# Altium schematic sheet auxiliary.SchDoc(auxiliary)
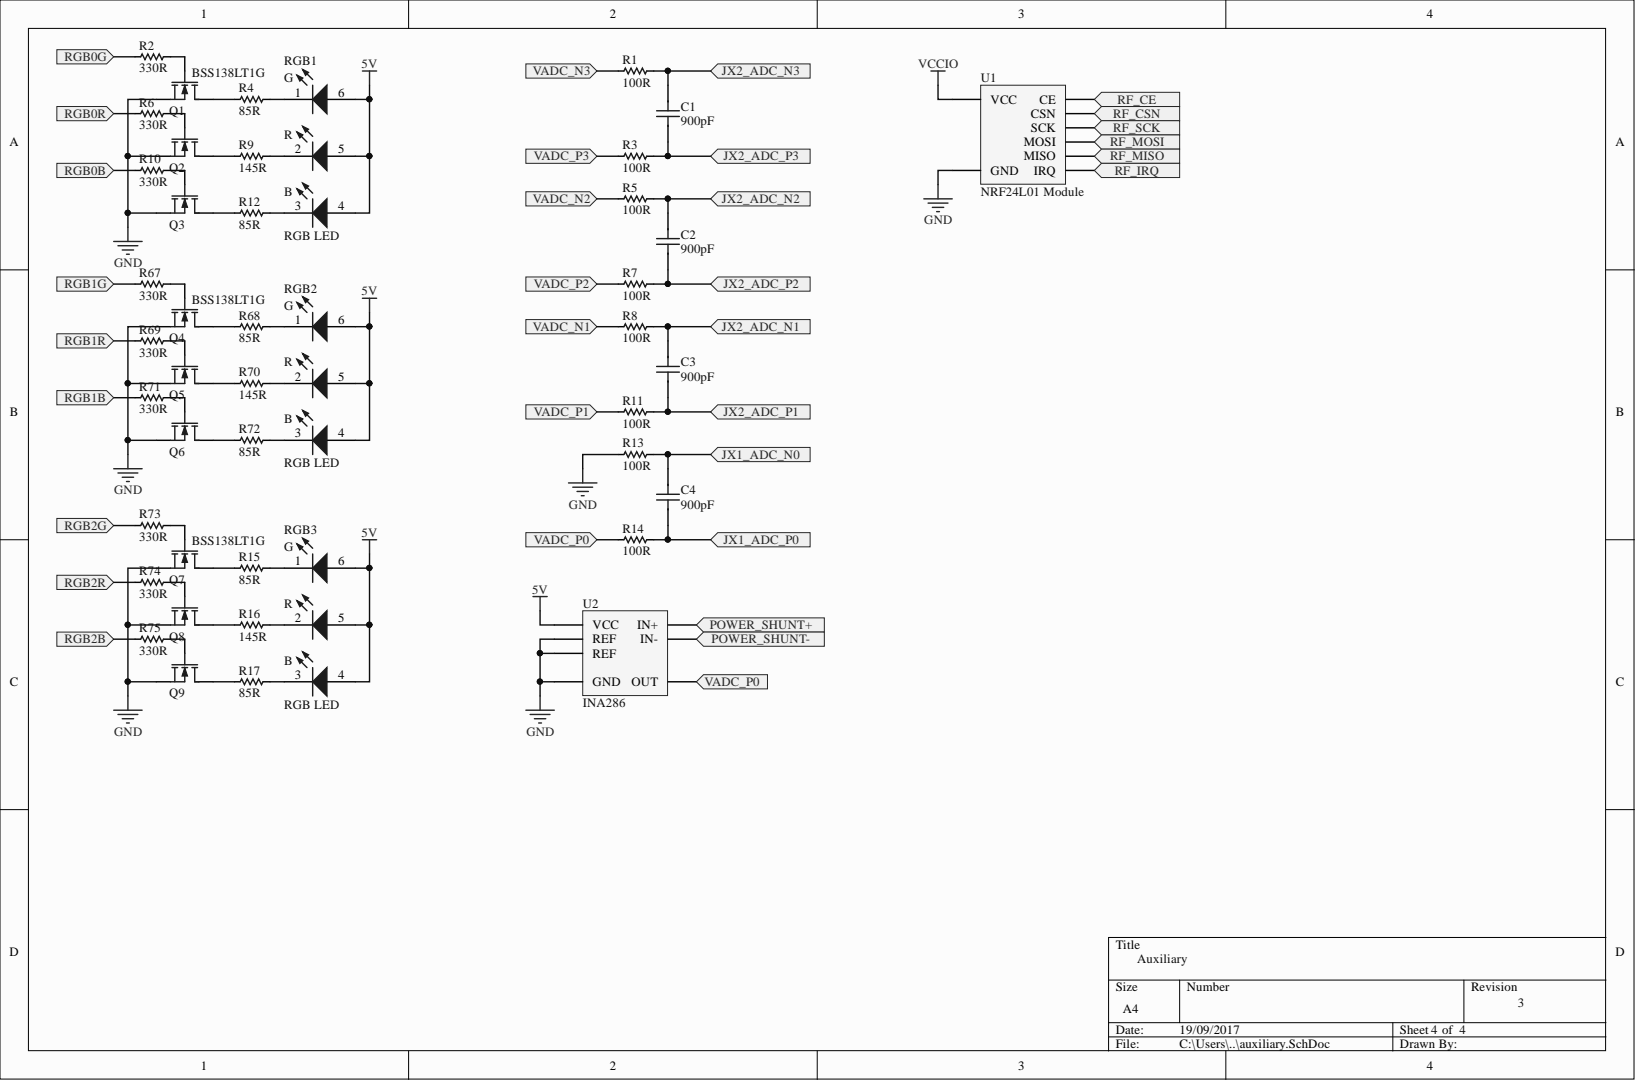
[diagram p0: auxiliary.SchDoc]

## Parts
C1  value 900pF  [CAP-0402]  (hidden comment: Capacitor)
C2  value 900pF  [CAP-0402]  (hidden comment: Capacitor)
C3  value 900pF  [CAP-0402]  (hidden comment: Capacitor)
C4  value 900pF  [CAP-0402]  (hidden comment: Capacitor)
Q1  BSS138LT1G  [SOT-23B]
Q2  BSS138LT1G  [SOT-23B]
Q3  BSS138LT1G  [SOT-23B]
Q4  BSS138LT1G  [SOT-23B]
Q5  BSS138LT1G  [SOT-23B]
Q6  BSS138LT1G  [SOT-23B]
Q7  BSS138LT1G  [SOT-23B]
Q8  BSS138LT1G  [SOT-23B]
Q9  BSS138LT1G  [SOT-23B]
R1  value 100R  [RES-0402]  (hidden comment: Resistor)
R2  value 330R  [RES-0805]  (hidden comment: Resistor)
R3  value 100R  [RES-0402]  (hidden comment: Resistor)
R4  value 85R  [RES-0805]  (hidden comment: Resistor)
R5  value 100R  [RES-0402]  (hidden comment: Resistor)
R6  value 330R  [RES-0805]  (hidden comment: Resistor)
R7  value 100R  [RES-0402]  (hidden comment: Resistor)
R8  value 100R  [RES-0402]  (hidden comment: Resistor)
R9  value 145R  [RES-0805]  (hidden comment: Resistor)
R10  value 330R  [RES-0805]  (hidden comment: Resistor)
R11  value 100R  [RES-0402]  (hidden comment: Resistor)
R12  value 85R  [RES-0805]  (hidden comment: Resistor)
R13  value 100R  [RES-0402]  (hidden comment: Resistor)
R14  value 100R  [RES-0402]  (hidden comment: Resistor)
R15  value 85R  [RES-0805]  (hidden comment: Resistor)
R16  value 145R  [RES-0805]  (hidden comment: Resistor)
R17  value 85R  [RES-0805]  (hidden comment: Resistor)
R67  value 330R  [RES-0805]  (hidden comment: Resistor)
R68  value 85R  [RES-0805]  (hidden comment: Resistor)
R69  value 330R  [RES-0805]  (hidden comment: Resistor)
R70  value 145R  [RES-0805]  (hidden comment: Resistor)
R71  value 330R  [RES-0805]  (hidden comment: Resistor)
R72  value 85R  [RES-0805]  (hidden comment: Resistor)
R73  value 330R  [RES-0805]  (hidden comment: Resistor)
R74  value 330R  [RES-0805]  (hidden comment: Resistor)
R75  value 330R  [RES-0805]  (hidden comment: Resistor)
RGB1  RGB LED  [RGB_LED]
RGB2  RGB LED  [RGB_LED]
RGB3  RGB LED  [RGB_LED]
U1  NRF24L01 Module  [NRF24L01 Module]
U2  INA286  [SOIC-8]

## Ports
JX1_ADC_N0, JX1_ADC_P0, JX2_ADC_N1, JX2_ADC_N2, JX2_ADC_N3, JX2_ADC_P1, JX2_ADC_P2, JX2_ADC_P3, POWER_SHUNT+, POWER_SHUNT-, RF_CE, RF_CSN, RF_IRQ, RF_MISO, RF_MOSI, RF_SCK, RGB0B, RGB0G, RGB0R, RGB1B, RGB1G, RGB1R, RGB2B, RGB2G, RGB2R, VADC_N1, VADC_N2, VADC_N3, VADC_P0, VADC_P1, VADC_P2, VADC_P3

## Nets
5V: RGB1.4 RGB1.5 RGB1.6 RGB2.4 RGB2.5 RGB2.6 RGB3.4 RGB3.5 RGB3.6 U2.6(VCC)
GND: Q1.2 Q2.2 Q3.2 Q4.2 Q5.2 Q6.2 Q7.2 Q8.2 Q9.2 R13.1 U1.2(GND) U2.2(GND) U2.3(REF) U2.7(REF)
VCCIO: U1.1(VCC)
JX1_ADC_N0: C4.2 R13.0 ; port JX1_ADC_N0
JX1_ADC_P0: C4.1 R14.0 ; port JX1_ADC_P0
JX2_ADC_N1: C3.2 R8.0 ; port JX2_ADC_N1
JX2_ADC_N2: C2.2 R5.0 ; port JX2_ADC_N2
JX2_ADC_N3: C1.2 R1.0 ; port JX2_ADC_N3
JX2_ADC_P1: C3.1 R11.0 ; port JX2_ADC_P1
JX2_ADC_P2: C2.1 R7.0 ; port JX2_ADC_P2
JX2_ADC_P3: C1.1 R3.0 ; port JX2_ADC_P3
RF_CE: U1.3(CE) ; port RF_CE
RF_CSN: U1.4(CSN) ; port RF_CSN
RF_IRQ: U1.8(IRQ) ; port RF_IRQ
RF_MISO: U1.7(MISO) ; port RF_MISO
RF_MOSI: U1.6(MOSI) ; port RF_MOSI
RF_SCK: U1.5(SCK) ; port RF_SCK
RGB0B: R10.1 ; port RGB0B
RGB0G: R2.1 ; port RGB0G
RGB0R: R6.1 ; port RGB0R
RGB1B: R71.1 ; port RGB1B
RGB1G: R67.1 ; port RGB1G
RGB1R: R69.1 ; port RGB1R
RGB2B: R75.1 ; port RGB2B
RGB2G: R73.1 ; port RGB2G
RGB2R: R74.1 ; port RGB2R
VADC_N1: R8.1 ; port VADC_N1
VADC_N2: R5.1 ; port VADC_N2
VADC_N3: R1.1 ; port VADC_N3
VADC_P0: R14.1 U2.5(OUT) ; port VADC_P0
VADC_P1: R11.1 ; port VADC_P1
VADC_P2: R7.1 ; port VADC_P2
VADC_P3: R3.1 ; port VADC_P3
NetQ1_1: Q1.1 R2.0
NetQ1_3: Q1.3 R4.1
NetQ2_1: Q2.1 R6.0
NetQ2_3: Q2.3 R9.1
NetQ3_1: Q3.1 R10.0
NetQ3_3: Q3.3 R12.1
NetQ4_1: Q4.1 R67.0
NetQ4_3: Q4.3 R68.1
NetQ5_1: Q5.1 R69.0
NetQ5_3: Q5.3 R70.1
NetQ6_1: Q6.1 R71.0
NetQ6_3: Q6.3 R72.1
NetQ7_1: Q7.1 R73.0
NetQ7_3: Q7.3 R15.1
NetQ8_1: Q8.1 R74.0
NetQ8_3: Q8.3 R16.1
NetQ9_1: Q9.1 R75.0
NetQ9_3: Q9.3 R17.1
NetR4_0: R4.0 RGB1.1
NetR9_0: R9.0 RGB1.2
NetR12_0: R12.0 RGB1.3
NetR15_0: R15.0 RGB3.1
NetR16_0: R16.0 RGB3.2
NetR17_0: R17.0 RGB3.3
NetR68_0: R68.0 RGB2.1
NetR70_0: R70.0 RGB2.2
NetR72_0: R72.0 RGB2.3
NetU2_1: U2.1(IN-)
NetU2_8: U2.8(IN+)

## Library data of the parts
Q1-Q9 = BSS138LT1G: N-Channel MOSFET; Published: 8-Jun-2000; PackageVersion: 1995/1996; PackageReference: E3; PackageDescription: TO, Flat Index; 3 In-Line, Axial Leads; Body Dia. 4.6mm; Lead Dia. 0.5 mm (max); LatestRevisionNote: Re-released for DXP Platform.; LatestRevisionDate: 17-Jul-2002; Component Kind: Standard; Publisher: Altium Limited; Library Name: SDUEmbedded.SchLib
RGB1-RGB3 = LTRB R8SF: Component Kind: Standard; Library Name: SDUEmbedded.SchLib
C1-C4 = Capacitor: Description: Capacitor; Component Kind: Standard; Library Name: SDUEmbedded.SchLib
R1-R17 R67-R75 = Resistor: Description: Resistor; Component Kind: Standard; Library Name: SDUEmbedded.SchLib
U1 = NRF24L01 Module: Component Kind: Standard; Library Name: SDUEmbedded.SchLib
U2 = INA286: Component Kind: Standard; Library Name: SDUEmbedded.SchLib
